# Altium schematic sheet Power.SchDoc
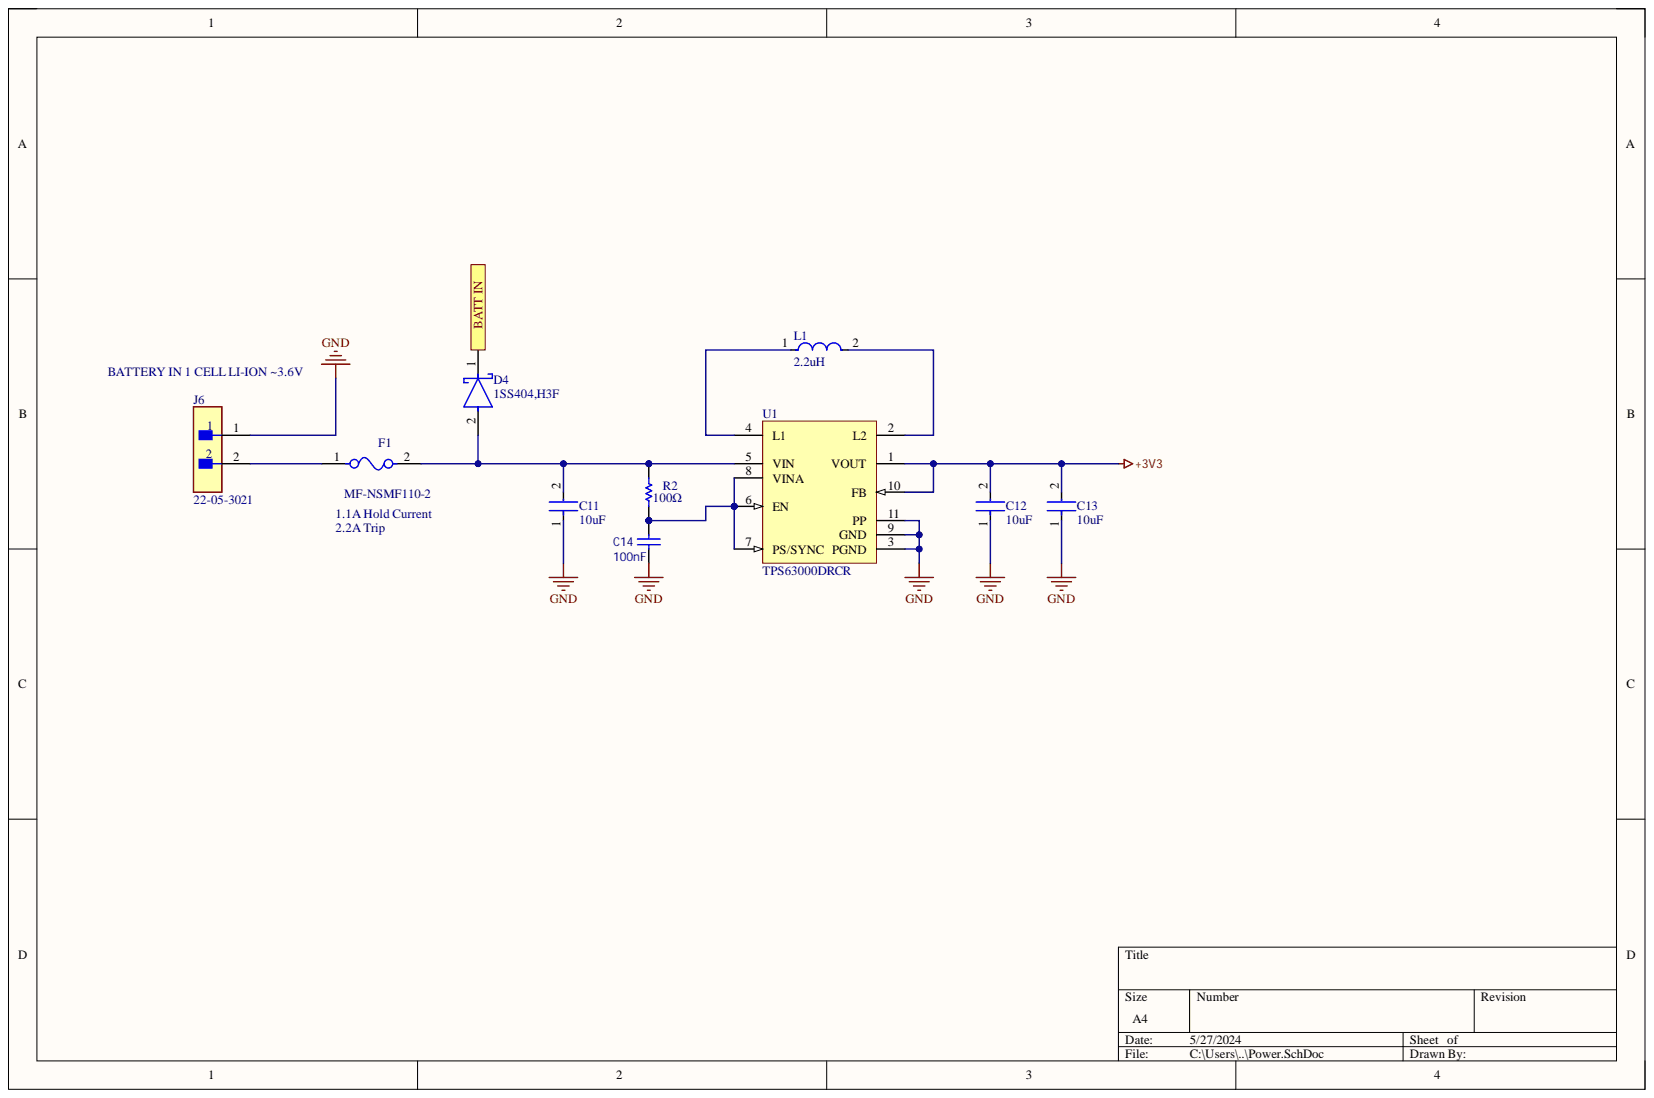
[diagram p0: Power.SchDoc]

## Parts
C11  10uF  [FP-C1608-080-IPC_A]
C12  10uF  [FP-C1608-080-IPC_A]
C13  10uF  [FP-C1608-080-IPC_A]
C14  value 100nF  Capacitance: 100nF  [CAPC1608X10N]  (hidden comment: C0603C104M5RACTU)
D4  1SS404,H3F  [FP-SOD-323-MFG]
F1  MF-NSMF110-2  Hold Current: 1.1A  [FP-MF-NSMF110-2-MFG]
J6  22-05-3021  [FP-22-05-3021-MFG]
L1  2.2uH  [FP-IHLP-2525BD-FP_2_413x3-MFG]
R2  100Ω  [RESC1609X50X30ML8T20]
U1  TPS63000DRCR  [DRC0010J_V]

## Ports
BATT IN

## Nets
+3V3: C12.2 C13.2 U1.1(VOUT) U1.10(FB)
GND: C11.1 C12.1 C13.1 C14.1 J6.1 U1.3(PGND) U1.9(GND) U1.11(PP)
BATT IN: D4.1 ; port BATT IN
NetC11_2: C11.2 D4.2 F1.2 R2.2 U1.5(VIN)
NetC14_2: C14.2 R2.1 U1.6(EN) U1.7(PS/SYNC) U1.8(VINA)
NetF1_1: F1.1 J6.2
NetL1_1: L1.1 U1.4(L1)
NetL1_2: L1.2 U1.2(L2)

## Library data of the parts
C11-C13 = CMP-2000-05442-2: CAP CER 10UF 25V X5R 0603; Dielectric Material: Ceramic; Tolerance: 20%; Capacitance: 10uF; Case Code (Imperial): 0603; Case Code (Metric): 1608; Case/Package: 0603; Component Kind: Standard; Construction: Flat; Depth: 0.8mm; Dielectric: X5R; Height: 0.8mm; Lead Free: Lead Free; Length: 1.6mm; Library Name: Altium Content Vault; Max Operating Temperature: 85°C; Min Operating Temperature: -55°C; Mount: Surface Mount; Package Quantity: 1; Packaging: Tape and Reel; REACH SVHC: No SVHC; Resistor Type: Ceramic; RoHS Compliant: Yes; Series: C; Termination: SMD/SMT; Thickness: 0.039inch; Voltage Rating: 25V; Voltage Rating (DC): 25V; Weight: 7.1E-05oz; Width: 0.8mm
C14 = CMP-00038-5: Cap Ceramic 0.1uF 50V X7R 20% SMD 0603 125C T/R; Dissipation Factor: 2.5%; Case Code (Imperial): 0603; Case Code (Metric): 1608; Case/Package: 0603; Component Kind: Standard; Contact Plating: Tin,Matte; Depth: 0.8mm; Dielectric: X7R; Dielectric Material: Ceramic; Height: 0.8mm; JLC_PN: C14663; Lead Pitch: 0.7mm; Length: 1.6mm; Max Operating Temperature: 125°C; Min Operating Temperature: -55°C; Mount: Surface Mount,PCB; Package Quantity: 1; Packaging: Tape and Reel; Radiation Hardening: No; REACH SVHC: No SVHC; Termination: SMD/SMT; Thickness: 0.034inch; Tolerance: 20%; Voltage Rating: 50V; Voltage Rating (DC): 50V; Width: 0.031inch; Library Name: UWA Aerospace
D4 = CMP-35905-000002-1: DIODE SCHOTTKY 20V 300MA USC; Element Configuration: Single; Max Repetitive Reverse Voltage (Vrrm): 25V; Average Rectified Current: 300mA; Case/Package: SOD; Component Kind: Standard; Contact Plating: Tin; Forward Current: 300mA; Library Name: Altium Content Vault; Max Operating Temperature: 100°C; Max Reverse Voltage (DC): 20V; Min Operating Temperature: -40°C; Mount: Surface Mount; Packaging: Tape and Reel
F1 = CMP-07400-000012-1: PTC RESET FUSE 6V 1.1A 1206; Manufacturer: Bourns; Automotive Grade: Grade 3; Current Rating: 100A; Resistance: 0.06-0.2Ω; Trip Current: 2.2A; Trip Time: 0.1s; Value: 0.06-0.2Ω; Ambient Temperature Range High: +85°C; Ambient Temperature Range Low: -40°C; Automotive: Yes; Category: Fuse; Component Kind: Standard; ComponentLink2Description: Datasheet; ComponentLink2URL: https://www.bourns.com/docs/Product-Datasheets/mfnsmf.pdf; Device Class L1: Circuit Protection; Device Class L2: PTC Resettable Fuses; Device Class L3: unset; Digikey Description: PTC RESET FUSE 6V 1.1A 1206; Height: 0.7mm; Lead Free: Yes; Library Name: Altium Content Vault; Manufacturer Part Number: MF-NSMF110-2; Mouser Description: Resettable Fuses - PPTC 1.1A 6V 0.06ohm; Octopart: https://octopart.com/mf-nsmf110-2-bourns-41795; Package: MF-NSMF110-2; RoHS: TRUE; Temperature: -40°C to +85°C; Temperature Range High: +85°C; Temperature Range Low: -40°C; Voltage Rating: 6V
J6 = CMP-04776-000166-1: CONN HEADER R/A 2POS 2.54MM; Approvals: UL; Contact Resistance: 10mR; Current Rating: 4A; Gender: Male; Glow Wire Compliant: Non-compliant; Max Voltage Rating (AC): 250V; Number of Circuits: 2; Color: White; Component Kind: Standard; Contact Material: Brass; Contact Mating Length: 0.264inch; Contact Plating: Tin; Contact Type: Male Pin; Depth: 12.83mm; Fastening Type: Friction; Flammability Rating: UL94 V-0; Halogen Free: Low Halogen; Height: 6.35mm; Housing Color: White; Housing Material: Nylon; Lead Free: Lead Free; Length: 5.08mm; Library Name: Altium Content Vault; Max Current Rating: 4A; Max Operating Temperature: 75°C; Min Operating Temperature: 0°C; Mount: Through Hole; Number of Contacts: 2; Number of Positions: 2; Number of Rows: 1; Orientation: Right Angle; Package Quantity: 3000; Packaging: Bulk; Pitch: 2.54mm; Radiation Hardening: No; Series: KK® 7478; Termination: Solder; Voltage Rating: 250V
L1 = CMP-02424-000562-1: Commercial Inductors, High Saturation Series 2.2uH 6.5A 34mΩ 20%; Core Material: Metal; DC Resistance (DCR): 34mR; Saturation Current: 14A; Self Resonant Frequency: 5MHz; Shielding: Shielded; Test Frequency: 100kHz; Case Code (Imperial): 2525; Case Code (Metric): 6363; Case/Package: 2525; Component Kind: Standard; Contact Plating: Tin; Current Rating: 6.5A; Depth: 6.72mm; Height: 2.4mm; Height - Seated (Max): 0.094inch; Inductance: 2.2uH; Inductance Tolerance: 20%; Lead Free: Lead Free; Length: 7.24mm; Library Name: Altium Content Vault; Max DC Current: 6.5A; Max Operating Temperature: 125°C; Military Standard: Not; Min Operating Temperature: -55°C; Mount: Surface Mount; Number of Pins: 2525; Package Quantity: 2000; Packaging: Tape and Reel; Radiation Hardening: No; RMS Current (Irms): 6.5A; Termination: SMD/SMT; Tolerance: 20%; Width: 0.255inch
R2 = CMP-2000-03824-5: Chip Resistor, 100 Ohm, +/- 1%, 100 mW,-55 to 155 degC, 0603 (1608 Metric), RoHS, Tape and Ree; Manufacturer: Vishay Semiconductor; Component Kind: Standard; ComponentLink1Description: Manufacturer URL; ComponentLink1URL: http://www.vishay.com/; ComponentLink2Description: Datasheet; ComponentLink2URL: http://www.vishay.com/docs/20035/dcrcwe3.pdf; DatasheetVersion: 06/2012; Library Name: Altium Content Vault; Mounting Technology: Surface Mount; PackageDescription: 2-Pin Surface Mount Device, Body 1.575 x 0.85 mm; PackageReference: 0603
U1 = CMP-019-00049-1: Switching Regulator, Current-mode, 0.8A, 1500kHz Switching Freq-Max, PDSO10; Frequency: 1.5MHz; Max Supply Voltage: 5.5V; Case/Package: HVSON; Component Kind: Standard; Max Operating Temperature: 85°C; Min Operating Temperature: -40°C; Pins: 10; RoHS Compliant: Yes; Library Name: Design 1 Group 15
